# Altium schematic sheet USB.SchDoc
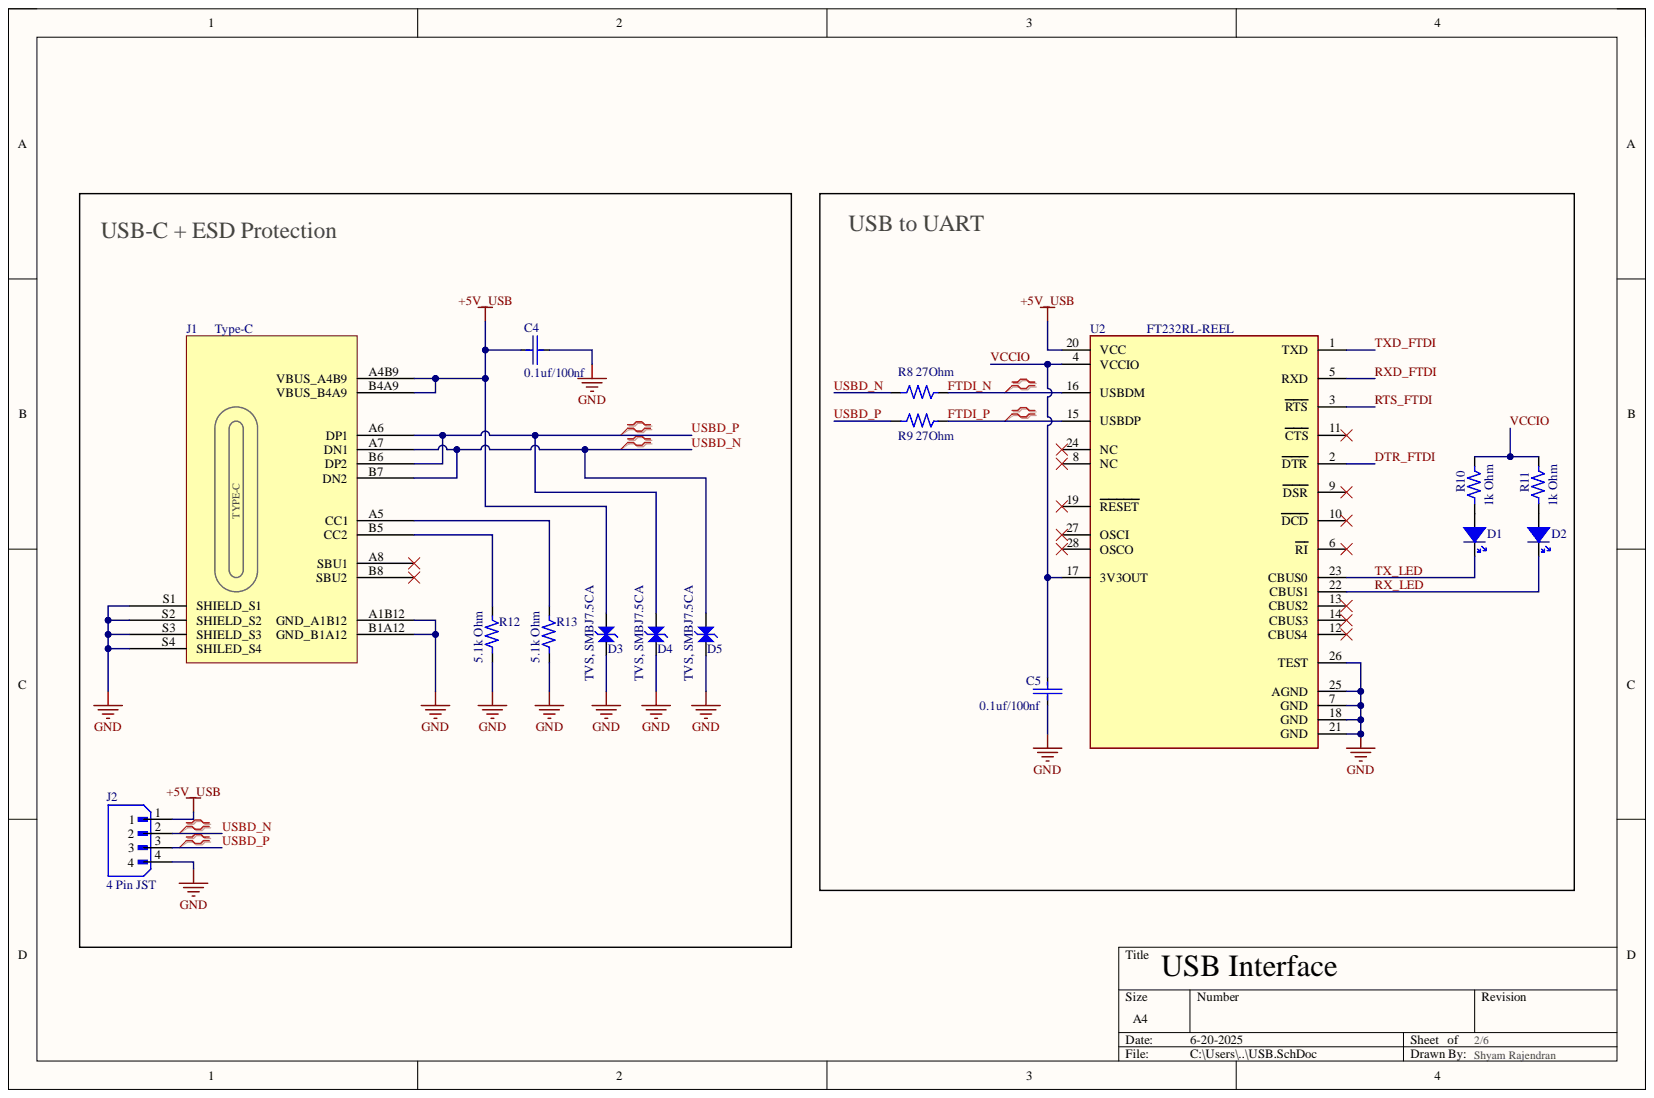
[diagram p0: USB.SchDoc]

## Parts
C4  0.1uf/100nf  [0.1uF Capacitor]
C5  0.1uf/100nf  [0.1uF Capacitor]
D1  Blue, 0805  [led blue]  (value not drawn)
D2  Blue, 0805  [led blue]  (value not drawn)
D3  TVS, SMBJ7.5CA  [TVS Diode]
D4  TVS, SMBJ7.5CA  [TVS Diode]
D5  TVS, SMBJ7.5CA  [TVS Diode]
J1  Type-C  [Type C]
J2  4 Pin JST  Manufacturer: JST  [JST_B4B-XH-A]
R8  27Ohm  [1k 0805 Resistor]
R9  27Ohm  [1k 0805 Resistor]
R10  1k Ohm  [1k 0805 Resistor]
R11  1k Ohm  [1k 0805 Resistor]
R12  5.1k Ohm  [RESC2012X60N]
R13  5.1k Ohm  [RESC2012X60N]
U2  FT232RL-REEL  [FP-SSOP-28-IPC_B]

## Nets
+5V_USB: C4.2 D3.2 J1.A4B9(VBUS_A4B9) J1.B4A9(VBUS_B4A9) J2.1 U2.20(VCC)
GND: C4.1 C5.1 D3.1 D4.1 D5.1 J1.A1B12(GND_A1B12) J1.B1A12(GND_B1A12) J1.S1(SHIELD_S1) J1.S2(SHIELD_S2) J1.S3(SHIELD_S3) J1.S4(SHILED_S4) J2.4 R12.1 R13.1 U2.7(GND) U2.18(GND) U2.21(GND) U2.25(AGND) U2.26(TEST)
DTR_FTDI: U2.2(DTR)
FTDI_N: R8.2 U2.16(USBDM)
FTDI_P: R9.2 U2.15(USBDP)
RTS_FTDI: U2.3(RTS)
RX_LED: D2.C U2.22(CBUS1)
RXD_FTDI: U2.5(RXD)
TX_LED: D1.C U2.23(CBUS0)
TXD_FTDI: U2.1(TXD)
USBD_N: D5.2 J1.A7(DN1) J1.B7(DN2) J2.2 R8.1
USBD_P: D4.2 J1.A6(DP1) J1.B6(DP2) J2.3 R9.1
VCCIO: C5.2 R10.2 R11.2 U2.4(VCCIO) U2.17(3V3OUT)
NetD1_A: D1.A R10.1
NetD2_A: D2.A R11.1
NetJ1_A5: J1.A5(CC1) R13.2
NetJ1_B5: J1.B5(CC2) R12.2

Not connected: J1 A8(SBU1) B8(SBU2); U2 6(RI) 8(NC) 9(DSR) 10(DCD) 11(CTS) 12(CBUS4) 13(CBUS2) 14(CBUS3) 19(RESET) 24(NC) 27(OSCI) 28(OSCO)

## Library data of the parts
C4 C5 = CC0805KRX7R9BB104: 0.1 uF, Multilayer Ceramic Capacitor, 50 V, ï¿½ 10%, X7R, 0805 [2012 Metric]; Manufacturer: Yageo; Insulation Resistance: 100GR; Value: 100nF; Voltage: 50V; Case Code (Imperial): 0805; Case Code (Metric): 2012; Case/Package: 0805; Category: Ceramic Capacitors; Component Kind: Standard; Depth: 1.25mm; Dielectric: X7R; Halogen Free: 5694HalogenFree; Height: 0.85mm; Lead Free: 5697LeadFree; Length: 2mm; Manufacturer Part Number: CC0805KRX7R9BB104; Material: Ceramic; Max Operating Temperature: 125°C; Min Operating Temperature: -55°C; Mounting Technology: Surface Mount; Package Quantity: 4000; Packaging: Tape and Reel; Pins: 2; Pricing: 4000=0.009, 12000=0.0088, 40000=0.0085, 80000=0.0083, 160000=0.008 (USD); Radiation Hardening: No; Reach SVHC Compliant: No; RoHS: Yes; RoHS Compliant: Yes; Series: CC; Stock: 2884000; Supplier: Future Electronics; Supplier Part Number: 2986263; Termination: SMD/SMT; Tolerance: 10%; Voltage Rating: 50V; Library Name: Sirius.SchLib
D1 D2 = LED Blue: Component Kind: Standard; Description: Blue, 0805; Library Name: Sirius.SchLib
D3-D5 = SMBJ7.5CA: SMBJ Series 5 W 9.21 V Bi-Directional Surface Mount TVS Diode - SMB; Manufacturer: Littelfuse; Max Reverse Leakage Current: 100uA; Reverse Voltage: 7.5V; Category: TVS Diodes; Component Kind: Standard; ComponentLink1Description: https://datasheet.ciiva.com/15143/getdatasheetpartid-149559-15143802.pdf?src-supplier=Verical; ComponentLink1URL: https://datasheet.ciiva.com/15143/getdatasheetpartid-149559-15143802.pdf?src-supplier=Verical; ComponentLink2Description: https://datasheet.ciiva.com/16487/littelfuse-tvs-diode-smbj-16487753.pdf?src-supplier=Future+Electronics; ComponentLink2URL: https://datasheet.ciiva.com/16487/littelfuse-tvs-diode-smbj-16487753.pdf?src-supplier=Future+Electronics; ComponentLink3Description: https://datasheet.ciiva.com/331/littelfuse-tvs-diode-smbj-datasheet-pdf-331604.pdf?src-supplier=Digikey; ComponentLink3URL: https://datasheet.ciiva.com/331/littelfuse-tvs-diode-smbj-datasheet-pdf-331604.pdf?src-supplier=Digikey; ComponentLink4Description: https://datasheet.ciiva.com/331/littelfuse-tvs-diode-smbj-datasheet-pdf-331605.pdf?src-supplier=Digikey; ComponentLink4URL: https://datasheet.ciiva.com/331/littelfuse-tvs-diode-smbj-datasheet-pdf-331605.pdf?src-supplier=Digikey; ComponentLink5Description: https://datasheet.ciiva.com/331/littelfuse-tvs-diode-smbj-datasheet-pdf-331606.pdf?src-supplier=Digikey; ComponentLink5URL: https://datasheet.ciiva.com/331/littelfuse-tvs-diode-smbj-datasheet-pdf-331606.pdf?src-supplier=Digikey; ComponentLink6Description: https://datasheet.ciiva.com/331/littelfuse-tvs-diode-smbj-datasheet-pdf-331616.pdf?src-supplier=Digikey; ComponentLink6URL: https://datasheet.ciiva.com/331/littelfuse-tvs-diode-smbj-datasheet-pdf-331616.pdf?src-supplier=Digikey; ComponentLink7Description: https://datasheet.ciiva.com/331/littelfuse-tvs-diode-smbj-datasheet-pdf-331617.pdf?src-supplier=Digikey; ComponentLink7URL: https://datasheet.ciiva.com/331/littelfuse-tvs-diode-smbj-datasheet-pdf-331617.pdf?src-supplier=Digikey; ComponentLink8Description: https://datasheet.ciiva.com/8062/13057-8062350.pdf?src-supplier=Element14; ComponentLink8URL: https://datasheet.ciiva.com/8062/13057-8062350.pdf?src-supplier=Element14; Manufacturer Part Number: SMBJ7.5CA; Pricing: 1=0.33, 10=0.215, 100=0.115, 500=0.113, 1000=0.111 (USD); RoHS: Yes; Stock: 3822; Supplier: Digikey; Supplier Part Number: SMBJ7.5CALFCT-ND; Library Name: Sirius.SchLib
J1 = Type-C: USB Connector, 16 Contact(s), Female, Right Angle, Surface Mount Terminal, Locking, Receptacle; Manufacturer: Molex; Manufacturer Part Number: 217179-0001; Current Rating: 1.25A; Number of Contacts: 16; USB Standard: USB 2.0; Voltage Rating: 30V; Category: Connectors; Component Kind: Standard; Contact Material: Nickel,Gold; Gender: Receptacle; Height: 3.36mm; Max Operating Temperature: 85°C; Min Operating Temperature: -40°C; Pricing: 1=1.05, 25=0.707, 100=0.609, 250=0.592, 500=0.575 (USD); RoHS: No; Stock: 7075; Supplier: Avnet; Supplier Part Number: 35AJ1973; Library Name: Sirius.SchLib
J2 = B4B-XH-A-JST: Board Connector, 4 Contact(s), 1 Row(s), Male, Straight, Solder Terminal,; Category: FFC / FPC; Component Kind: Standard; ComponentLink1Description: https://datasheet.ciiva.com/14155/xh-series-eng-tds-14155433.pdf?src-supplier=Distrelec; ComponentLink1URL: https://datasheet.ciiva.com/14155/xh-series-eng-tds-14155433.pdf?src-supplier=Distrelec; Manufacturer Part Number: B4B-XH-A; Orientation: 5491OrientationType; Pricing: 1=0.17, 10=0.149, 100=0.1262, 500=0.1126, 1000=0.10721, 5000=0.09569, 10000=0.09112 (USD); RoHS: Yes; Stock: 199106; Supplier: Digikey; Supplier Part Number: 455-B4B-XH-A-ND; Library Name: Sirius.SchLib
R8 R9 = RT0805FRE0727RL: 27 Ohm, Res Thin Film 0805 1% 1/8W ±50ppm/°C Molded SMD SMD Paper T/R; Manufacturer: Yageo; Max Power Dissipation: 125mW; Value: 27R; Voltage Rating: 150V; Case Code (Imperial): 0805; Case Code (Metric): 2012; Case/Package: 0805; Category: Chip SMD Resistors; Component Kind: Standard; ComponentLink1Description: https://datasheet.ciiva.com/2561/pyu-rt-1-to-0-05-rohs-l-5-2561109.pdf?src-supplier=Digikey; ComponentLink1URL: https://datasheet.ciiva.com/2561/pyu-rt-1-to-0-05-rohs-l-5-2561109.pdf?src-supplier=Digikey; ComponentLink2Description: https://datasheet.ciiva.com/8889/pyu-rt-1-to-0-05-rohs-l-4-8889865.pdf?src-supplier=Avnet; ComponentLink2URL: https://datasheet.ciiva.com/8889/pyu-rt-1-to-0-05-rohs-l-4-8889865.pdf?src-supplier=Avnet; ComponentLink3Description: https://datasheet.ciiva.com/7942/1392914-7942123.pdf?src-supplier=Element14; ComponentLink3URL: https://datasheet.ciiva.com/7942/1392914-7942123.pdf?src-supplier=Element14; ComponentLink4Description: https://datasheet.ciiva.com/7942/1392914-7942124.pdf?src-supplier=Element14; ComponentLink4URL: https://datasheet.ciiva.com/7942/1392914-7942124.pdf?src-supplier=Element14; Contact Plating: Tin,Matte; Height: 0.024inch; Length: 0.079inch; Manufacturer Part Number: RT0805FRE0727RL; Max Operating Temperature: 125°C; Min Operating Temperature: -55°C; Number of Terminations: 2; Package Quantity: 5000; Packaging: Tape and Reel; Pins: 2; Power Rating: 125mW; Pricing: 5000=0.0055, 20000=0.0053, 50000=0.0051, 125000=0.005, 200000=0.0048 (USD); Reach SVHC Compliant: Unknown; Resistance Tolerance: 1%; Resistor Type: Thin Film; RoHS: Yes; RoHS Compliant: Yes; Series: RT; Stock: 30000; Supplier: Future Electronics; Supplier Part Number: 9105398; Temperature Coefficient: 50ppm/°C; Termination: SMD/SMT; Tolerance: 1%; Voltage Rating (DC): 150V; Width: 0.049inch; Library Name: Sirius.SchLib
R10 R11 = RC0805FR-071KL: 1k Ohm, 0805, SMD Chip Resistor , ï¿½ 1%, 125 mW,[2012 Metric], Thick Film, General Purpose; Manufacturer: Yageo; Max Power Dissipation: 125mW; Temperature Coefficient: 100ppm/°C; Value: 1kR; Voltage Rating: 150V; Case Code (Imperial): 0805; Case Code (Metric): 2012; Case/Package: 0805; Category: Chip SMD Resistors; Component Kind: Standard; Construction: Rectangular; Depth: 1.25mm; Features: Moisture Resistant; Height: 0.024inch; Lead Free: Lead Free; Length: 0.079inch; Manufacturer Part Number: RC0805FR-071KL; Max Operating Temperature: 155°C; Military Standard: Not; Min Operating Temperature: -55°C; Number of Terminations: 2; Package Quantity: 1; Packaging: Tape and Reel; Pins: 2; Power Rating: 125mW; Pricing: 5000=0.0021, 20000=0.002, 100000=0.0019, 200000=0.0018, 625000=0.0017 (USD); Reach SVHC Compliant: No; Resistance Tolerance: 1%; Resistor Type: Thick Film; RoHS: Yes; RoHS Compliant: Yes; Series: RC; Stock: 5450000; Supplier: Future Electronics; Supplier Part Number: 8126013; Termination: SMD/SMT; Tolerance: 1%; Voltage Rating (AC): 150V; Voltage Rating (DC): 150V; Width: 0.049inch; Library Name: Sirius.SchLib
R12 R13 = RC0805FR-075K1L: 5.1k Ohm, 0805, 0.125W, 150V, 1% +/-Tol, 100ppm/Cel, Surface Mount; Manufacturer: Yageo; Additional Feature: LASER TRIMMABLE; Package Height: 0.5mm; Package Length: 2mm; Package Width: 1.25mm; Power: 125mW; Rated Temperature: 70°C; Temperature Coefficient (ppm/Cel): 100; Value: 5.1kR; Case/Package: 0805; Category: Chip SMD Resistors; Component Kind: Standard; ComponentLink1Description: https://datasheet.ciiva.com:443/pdfs/VipMasterIC/IC/YAGO/YAGO-S-A0008145938/YAGO-S-A0008145938-1.pdf?src-supplier=IHS+Markit; ComponentLink1URL: https://datasheet.ciiva.com:443/pdfs/VipMasterIC/IC/YAGO/YAGO-S-A0008145938/YAGO-S-A0008145938-1.pdf?src-supplier=IHS+Markit; ComponentLink2Description: https://datasheet.ciiva.com/11808/0900766b8106beab-11808171.pdf?src-supplier=Rs; ComponentLink2URL: https://datasheet.ciiva.com/11808/0900766b8106beab-11808171.pdf?src-supplier=Rs; ComponentLink3Description: https://datasheet.ciiva.com/2456/pyu-r-int-thick-7-2456979.pdf?src-supplier=Digikey; ComponentLink3URL: https://datasheet.ciiva.com/2456/pyu-r-int-thick-7-2456979.pdf?src-supplier=Digikey; ComponentLink4Description: https://datasheet.ciiva.com/2456/pyu-r-int-thick-7-2456980.pdf?src-supplier=Digikey; ComponentLink4URL: https://datasheet.ciiva.com/2456/pyu-r-int-thick-7-2456980.pdf?src-supplier=Digikey; ComponentLink5Description: https://datasheet.ciiva.com/15189/getdatasheetpartid-326574-15189996.pdf?src-supplier=Verical; ComponentLink5URL: https://datasheet.ciiva.com/15189/getdatasheetpartid-326574-15189996.pdf?src-supplier=Verical; ComponentLink6Description: https://datasheet.ciiva.com/2456/pyu-r-int-thick-7-2456978.pdf?src-supplier=Digikey; ComponentLink6URL: https://datasheet.ciiva.com/2456/pyu-r-int-thick-7-2456978.pdf?src-supplier=Digikey; Construction: Chip; JESD-609 Code: e3; Manufacturer Part Number: RC0805FR-075K1L; Max Operating Temperature: 155°C; Min Operating Temperature: -55°C; Mounting Technology: Surface Mount; Package Shape: Rectangular; Packaging: Tape and Reel; Pins: 2; Pricing: 5000=0.0021, 20000=0.002, 100000=0.0019, 200000=0.0018, 625000=0.0017 (USD); Reach SVHC Compliant: Yes; Resistor Type: FIXED RESISTOR; RoHS: Yes; RoHS Compliant: Yes; Stock: 15000; Supplier: Future Electronics; Supplier Part Number: 5647425; Technology: METAL GLAZE/THICK FILM; Terminal Finish: Nickel; Termination: Wraparound; Tolerance: 1%; Working Voltage: 150V; Library Name: Sirius.SchLib
U2 = FT232RL-REEL: USB Bus Controller, CMOS, PDSO28; Manufacturer: FTDI; Clock Frequency: 48MHz; Max Supply Current: 100uA; Max Supply Voltage: 5.25V; Min Supply Voltage: 3.3V; Package Body Material: Plastic; Qualification Status: Not Qualified; Case/Package: SSOP; Category: Interface ICs; Component Kind: Standard; ComponentLink1Description: https://datasheet.ciiva.com:443/pdfs/VipMasterIC/IC/FTDI/FTDI-S-A0007980421/FTDI-S-A0007980421-1.pdf?src-supplier=IHS+Markit; ComponentLink1URL: https://datasheet.ciiva.com:443/pdfs/VipMasterIC/IC/FTDI/FTDI-S-A0007980421/FTDI-S-A0007980421-1.pdf?src-supplier=IHS+Markit; ComponentLink2Description: https://datasheet.ciiva.com/4787/ds-ft232r-11534-4787658.pdf?src-supplier=Mouser; ComponentLink2URL: https://datasheet.ciiva.com/4787/ds-ft232r-11534-4787658.pdf?src-supplier=Mouser; ComponentLink3Description: https://datasheet.ciiva.com/15150/getdatasheetpartid-643207-15150506.pdf?src-supplier=Verical; ComponentLink3URL: https://datasheet.ciiva.com/15150/getdatasheetpartid-643207-15150506.pdf?src-supplier=Verical; ComponentLink4Description: https://datasheet.ciiva.com/1787/ds-ft232r-1787048.pdf?src-supplier=Digikey; ComponentLink4URL: https://datasheet.ciiva.com/1787/ds-ft232r-1787048.pdf?src-supplier=Digikey; ComponentLink5Description: https://datasheet.ciiva.com/1787/ds-ft232r-1787047.pdf?src-supplier=Digikey; ComponentLink5URL: https://datasheet.ciiva.com/1787/ds-ft232r-1787047.pdf?src-supplier=Digikey; ComponentLink6Description: https://datasheet.ciiva.com/1787/ds-ft232r-1787049.pdf?src-supplier=Digikey; ComponentLink6URL: https://datasheet.ciiva.com/1787/ds-ft232r-1787049.pdf?src-supplier=Digikey; ComponentLink7Description: https://datasheet.ciiva.com/16200/ds-ft232r-16200652.pdf?src-supplier=Future+Electronics; ComponentLink7URL: https://datasheet.ciiva.com/16200/ds-ft232r-16200652.pdf?src-supplier=Future+Electronics; Height - Seated (Max): 2mm; JESD-30 Code: R-PDSO-G28; Length: 10.2mm; Manufacturer Part Number: FT232RL-REEL; Max Operating Temperature: 85°C; Min Operating Temperature: -40°C; Moisture Sensitivity Level: 3; Package Shape: Rectangular; Package Style: SMALL OUTLINE, SHRINK PITCHMeter; Pins: 28; Pricing: 1=4.8, 10=4.35, 50=4.15, 100=3.95, 250=3.55, 500=3.15002, 1000=2.95001, 2000=2.95002 (USD); Reach SVHC Compliant: Yes; RoHS: Yes; RoHS Compliant: Yes; Stock: 4321; Supplier: Digikey; Supplier Part Number: 768-1007-1-ND; Surface Mount: Yes; Technology: CMOS; Temperature Grade: INDUSTRIAL; Terminal Form: Gull Wing; Terminal Pitch: 0.65mm; Terminal Position: DUAL; Width: 5.3mm; Library Name: Sirius.SchLib
